# Altium schematic sheet mini_WR.SchDoc
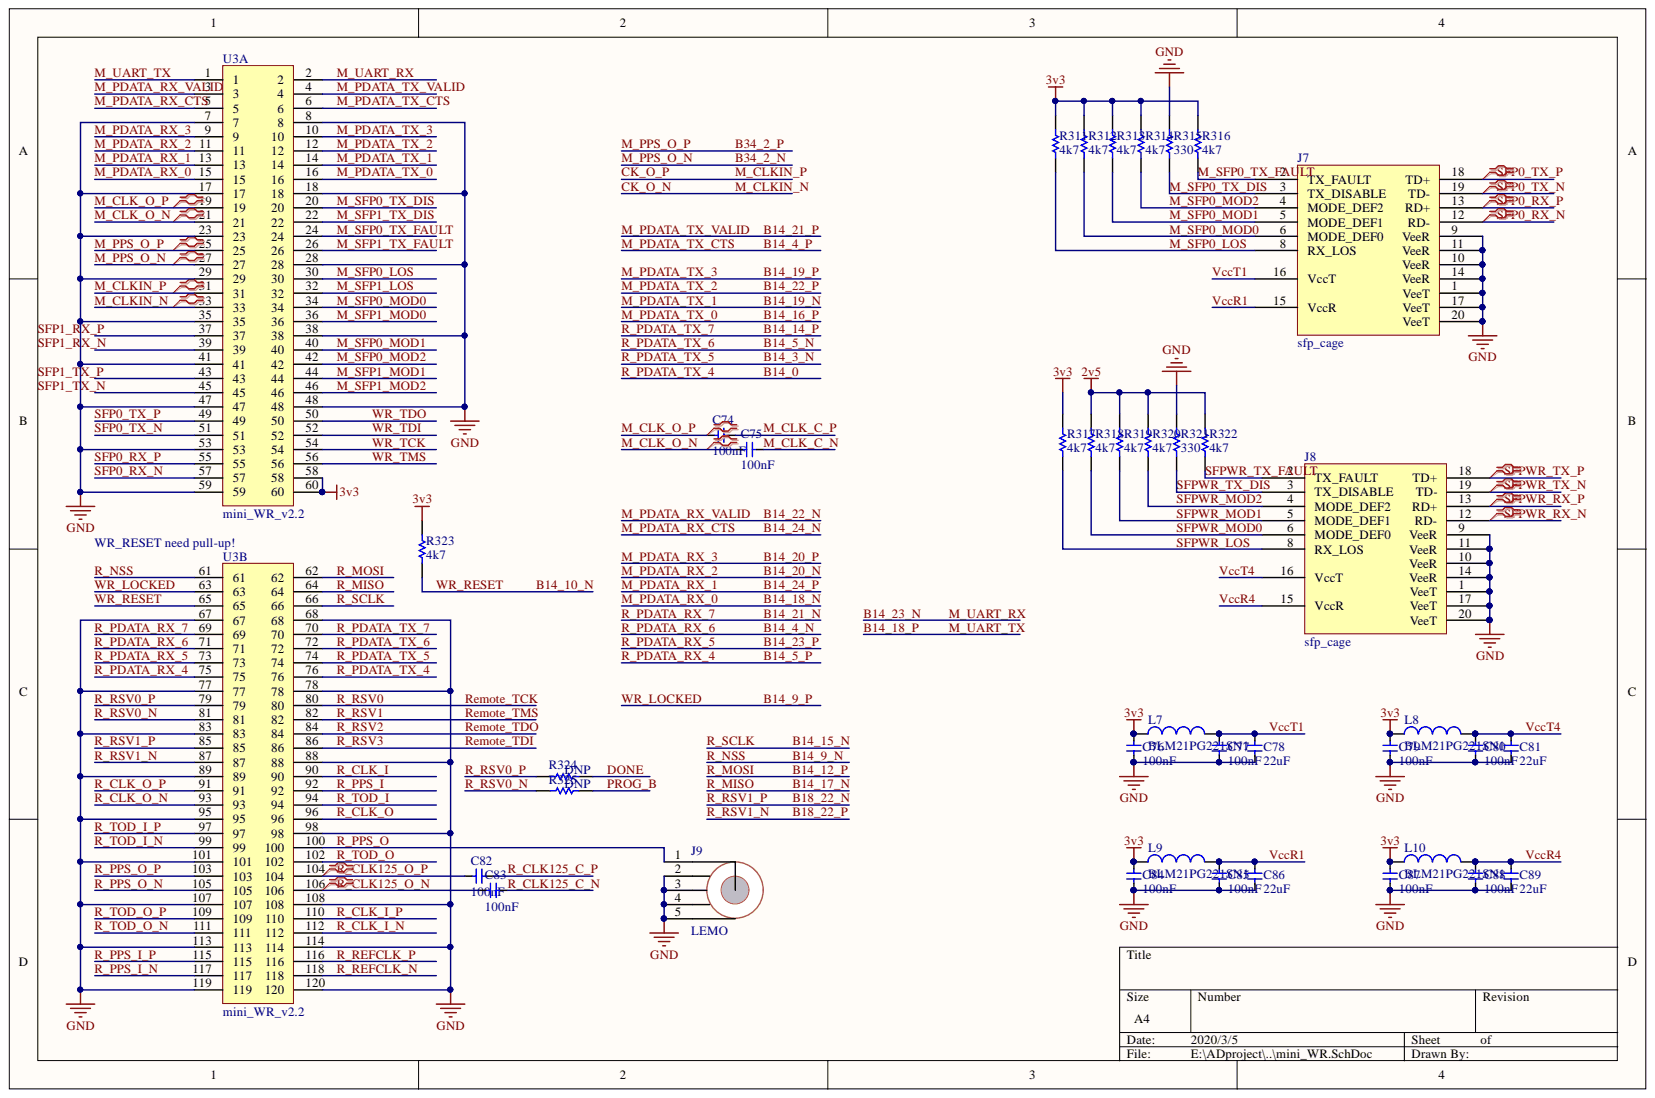
[diagram p0: mini_WR.SchDoc]

## Parts
C74  value 100nF  [0402]
C75  value 100nF  [0402]
C76  value 100nF  [0402]
C77  value 100nF  [0402]
C78  value 22uF  [0603]
C79  value 100nF  [0402]
C80  value 100nF  [0402]
C81  value 22uF  [0603]
C82  value 100nF  [0402]
C83  value 100nF  [0402]
C84  value 100nF  [0402]
C85  value 100nF  [0402]
C86  value 22uF  [0603]
C87  value 100nF  [0402]
C88  value 100nF  [0402]
C89  value 22uF  [0603]
J7  sfp_cage  [SFP_cage]
J8  sfp_cage  [SFP_cage]
J9  LEMO  [LEMO_00]
L7  BLM21PG221SN1  [L0805Y]
L8  BLM21PG221SN1  [L0805Y]
L9  BLM21PG221SN1  [L0805Y]
L10  BLM21PG221SN1  [L0805Y]
R311  value 4k7  [RESC0603(1608)_N]
R312  value 4k7  [RESC0603(1608)_N]
R313  value 4k7  [RESC0603(1608)_N]
R314  value 4k7  [RESC0603(1608)_N]
R315  value 330  [RESC0603(1608)_N]
R316  value 4k7  [RESC0603(1608)_N]
R317  value 4k7  [RESC0603(1608)_N]
R318  value 4k7  [RESC0603(1608)_N]
R319  value 4k7  [RESC0603(1608)_N]
R320  value 4k7  [RESC0603(1608)_N]
R321  value 330  [RESC0603(1608)_N]
R322  value 4k7  [RESC0603(1608)_N]
R323  value 4k7  [0402]
R324  value DNP  [0402]
R325  value DNP  [0402]
U3  mini_WR_v2.2  [mini-wr_v2.2]  (parts A,B)

## Nets
2v5: R318.2 R319.2 R320.2 R322.2
3v3: C76.2 C79.2 C84.2 C87.2 L7.1 L8.1 L9.1 L10.1 R311.2 R312.2 R313.2 R314.2 R316.2 R317.2 R323.2 U3.58 U3.60
GND: C76.1 C77.1 C78.1 C79.1 C80.1 C81.1 C84.1 C85.1 C86.1 C87.1 C88.1 C89.1 J7.1(VeeT) J7.9(VeeR) J7.10(VeeR) J7.11(VeeR) J7.14(VeeR) J7.17(VeeT) J7.20(VeeT) J7.42 J8.1(VeeT) J8.9(VeeR) J8.10(VeeR) J8.11(VeeR) J8.14(VeeR) J8.17(VeeT) J8.20(VeeT) J8.42 J9.2 J9.3 J9.4 J9.5 R315.2 R321.2 U3.7 U3.8 U3.17 U3.18 U3.23 U3.28 U3.29 U3.35 U3.38 U3.41 U3.47 U3.48 U3.53 U3.59 U3.67 U3.68 U3.77 U3.78 U3.83 U3.88 U3.89 U3.95 U3.98 U3.101 U3.107 U3.108 U3.113 U3.114 U3.119 U3.120
B14_0: U3.76
B14_3_N: U3.74
B14_4_N: U3.71
B14_4_P: U3.6
B14_5_N: U3.72
B14_5_P: U3.75
B14_9_N: U3.61
B14_9_P: U3.63
B14_10_N: R323.1 U3.65
B14_12_P: U3.62
B14_14_P: U3.70
B14_15_N: U3.66
B14_16_P: U3.16
B14_17_N: U3.64
B14_18_N: U3.15
B14_18_P: U3.1
B14_19_N: U3.14
B14_19_P: U3.10
B14_20_N: U3.11
B14_20_P: U3.9
B14_21_N: U3.69
B14_21_P: U3.4
B14_22_N: U3.3
B14_22_P: U3.12
B14_23_N: U3.2
B14_23_P: U3.73
B14_24_N: U3.5
B14_24_P: U3.13
B18_22_N: U3.85
B18_22_P: U3.87
B34_2_N: U3.27
B34_2_P: U3.25
CK_O_N: U3.33
CK_O_P: U3.31
DONE: R324.2
M_CLK_C_N: C75.1
M_CLK_C_P: C74.1
M_CLK_O_N: C75.2 U3.21
M_CLK_O_P: C74.2 U3.19
M_SFP0_LOS: J7.8(RX_LOS) R311.1 U3.30
M_SFP0_MOD0: J7.6(MODE_DEF0) R312.1 U3.34
M_SFP0_MOD1: J7.5(MODE_DEF1) R313.1 U3.40
M_SFP0_MOD2: J7.4(MODE_DEF2) R314.1 U3.42
M_SFP0_TX_DIS: J7.3(TX_DISABLE) R315.1 U3.20
M_SFP0_TX_FAULT: J7.2(TX_FAULT) R316.1 U3.24
M_SFP1_LOS: U3.32
M_SFP1_MOD0: U3.36
M_SFP1_MOD1: U3.44
M_SFP1_MOD2: U3.46
M_SFP1_TX_DIS: U3.22
M_SFP1_TX_FAULT: U3.26
PROG_B: R325.2
R_CLK125_C_N: C83.1
R_CLK125_C_P: C82.1
R_CLK125_O_N: C83.2 U3.106
R_CLK125_O_P: C82.2 U3.104
R_CLK_I: U3.90
R_CLK_I_N: U3.112
R_CLK_I_P: U3.110
R_CLK_O: U3.96
R_CLK_O_N: U3.93
R_CLK_O_P: U3.91
R_PPS_I: U3.92
R_PPS_I_N: U3.117
R_PPS_I_P: U3.115
R_PPS_O: J9.1 U3.100
R_PPS_O_N: U3.105
R_PPS_O_P: U3.103
R_REFCLK_N: U3.118
R_REFCLK_P: U3.116
R_RSV0: U3.80
R_RSV0_N: R325.1 U3.81
R_RSV0_P: R324.1 U3.79
R_RSV1: U3.82
R_RSV2: U3.84
R_RSV3: U3.86
R_TOD_I: U3.94
R_TOD_I_N: U3.99
R_TOD_I_P: U3.97
R_TOD_O: U3.102
R_TOD_O_N: U3.111
R_TOD_O_P: U3.109
SFP0_RX_N: J7.12(RD-) U3.57
SFP0_RX_P: J7.13(RD+) U3.55
SFP0_TX_N: J7.19(TD-) U3.51
SFP0_TX_P: J7.18(TD+) U3.49
SFPWR_LOS: J8.8(RX_LOS) R317.1
SFPWR_MOD0: J8.6(MODE_DEF0) R318.1
SFPWR_MOD1: J8.5(MODE_DEF1) R319.1
SFPWR_MOD2: J8.4(MODE_DEF2) R320.1
SFPWR_RX_N: J8.12(RD-)
SFPWR_RX_P: J8.13(RD+)
SFPWR_TX_DIS: J8.3(TX_DISABLE) R321.1
SFPWR_TX_FAULT: J8.2(TX_FAULT) R322.1
SFPWR_TX_N: J8.19(TD-)
SFPWR_TX_P: J8.18(TD+)
VccR1: C85.2 C86.2 J7.15(VccR) L9.2
VccR4: C88.2 C89.2 J8.15(VccR) L10.2
VccT1: C77.2 C78.2 J7.16(VccT) L7.2
VccT4: C80.2 C81.2 J8.16(VccT) L8.2
WR_TCK: U3.54
WR_TDI: U3.52
WR_TDO: U3.50
WR_TMS: U3.56
SFP1_RX_N:
SFP1_RX_P:
SFP1_TX_N:
SFP1_TX_P:

Not connected: U3 37 39 43 45

## Library data of the parts
C74-C89 = CapSMT: Capacitor (Surface Mount); Component Kind: Standard; Signal Integrity: Cap; Simulation: CAP; Library Name: LS1_full_size.SCHLIB; Publisher: Altium Limited
L7-L10 = Inductor: Code_IEC: 1005; Value: 10mH; Code_JEITA: 0402; Component Kind: Standard; Description: Inductor; LatestRevisionDate: 17-Jul-2002; LatestRevisionNote: Re-released for DXP Platform.; Library Name: Mini_WR_core.SCHLIB; PackageReference: 0402-A; Published: 8-Jun-2000; Publisher: Altium Limited
R311-R325 = CMP-1012-00454-1: Case-EIA: 0603; Case-Metric: 1608; Power: 0.1 W; Tolerance: 1 %; Component Kind: Standard; Library Name: Altium Content Vault; Technology: SMT
U3 = mini_WR_v2.2: mini_WR area; Component Kind: Standard; Library Name: general.SchLib
J7 J8 = sfp_cage: Component Kind: Standard; Library Name: general.SchLib
J9 = LEMO: Component Kind: Standard; Library Name: general.SchLib
